# Altium schematic sheet AFE.SchDoc
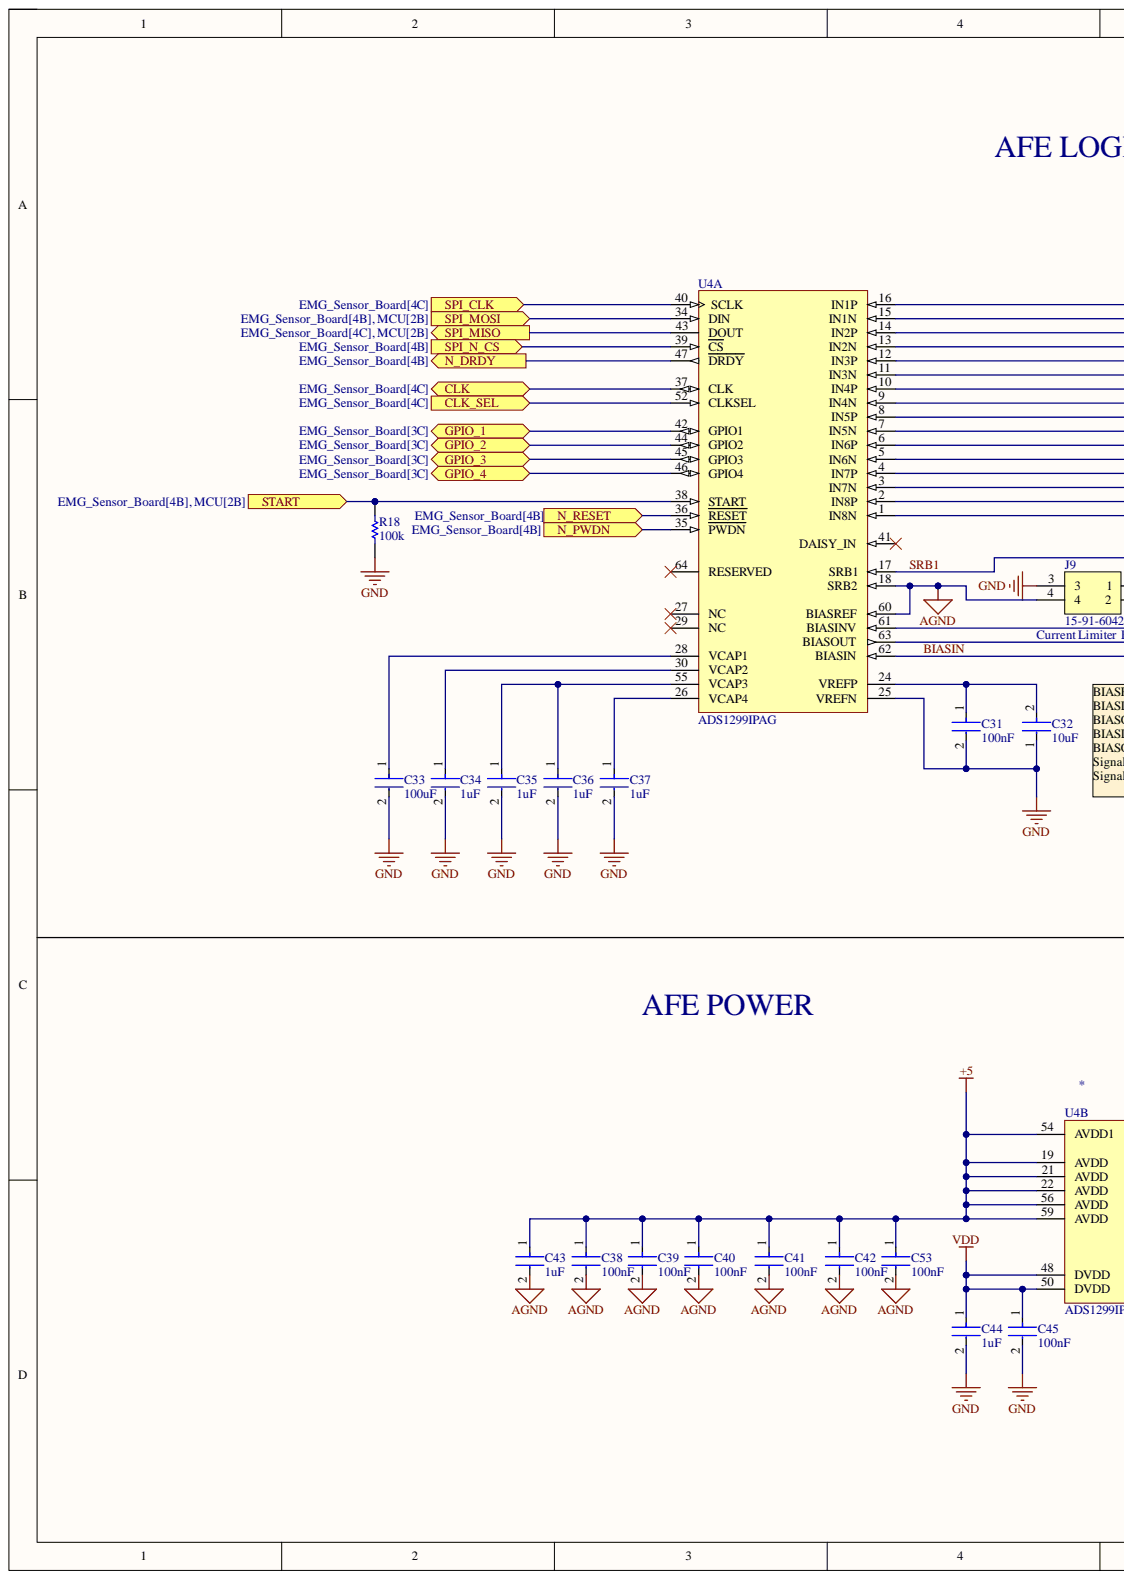
[diagram p0: AFE.SchDoc, left]
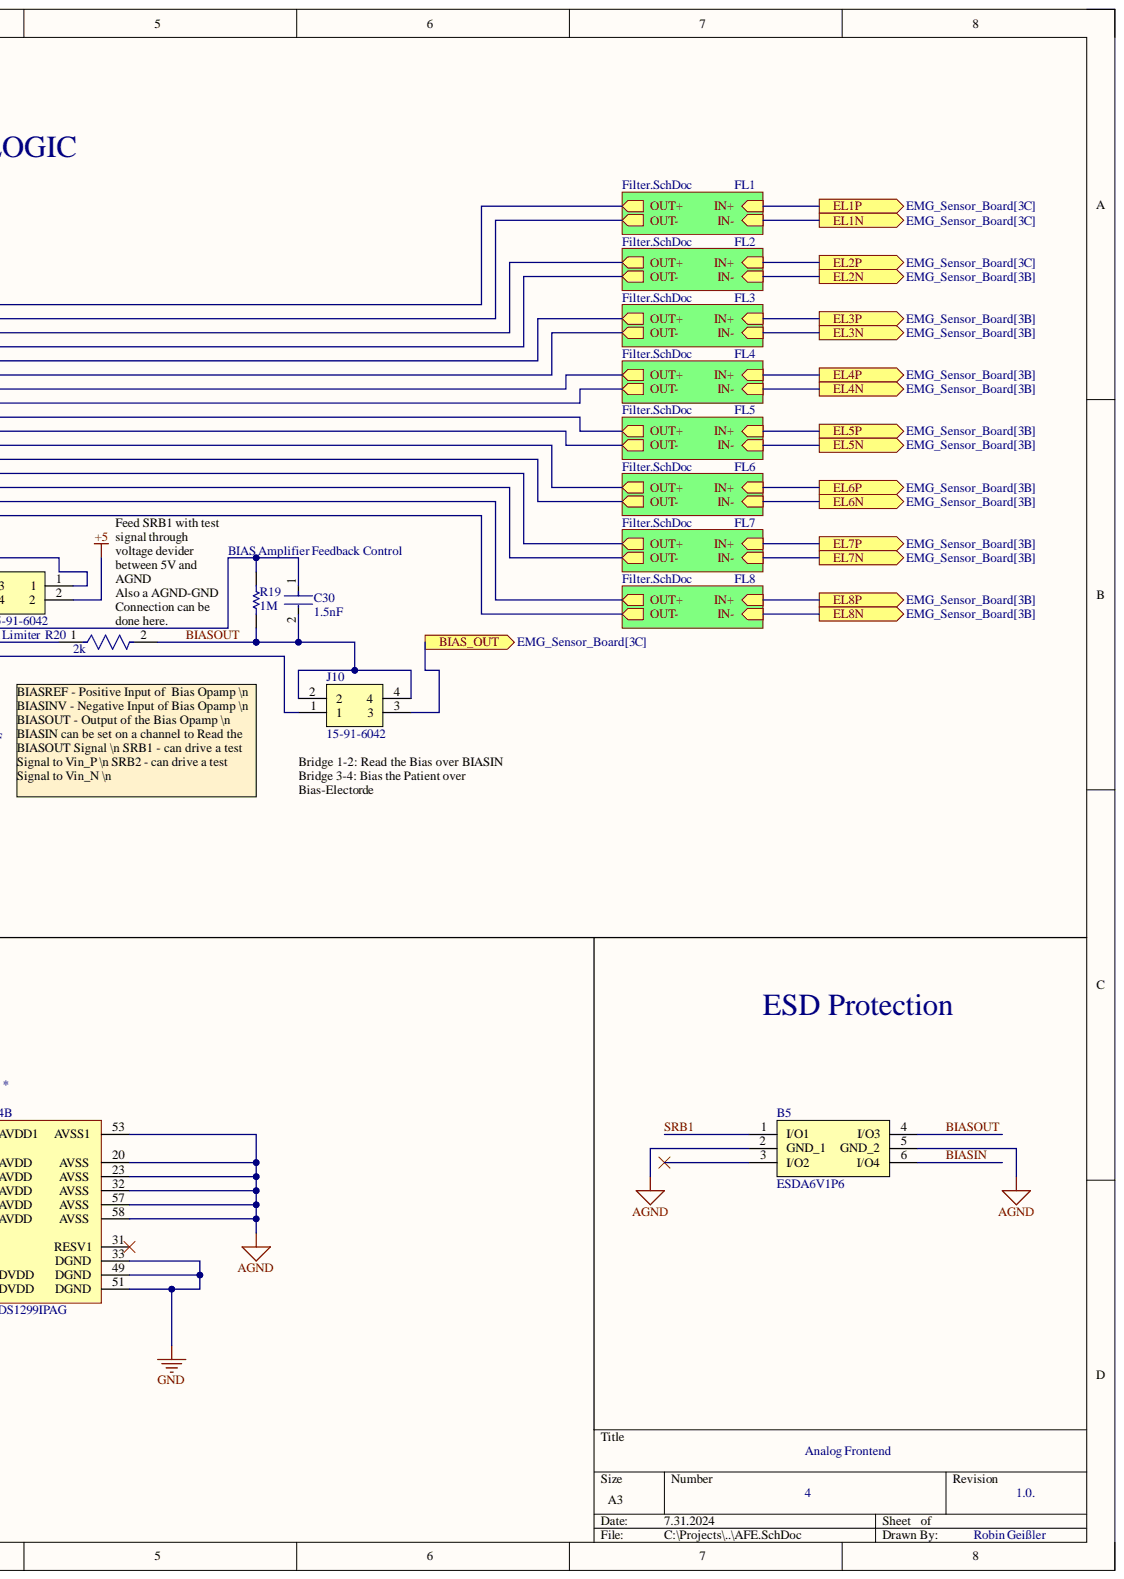
[diagram p1: AFE.SchDoc, right]

## Parts
B5  ESDA6V1P6  [ESDA6V1P6]
C30  1.5nF  [FP-GRM188-0_1-e0_2_0_5-IPC_B]
C31  100nF  [FP-CGA3E-0_3-0_1-IPC_A]
C32  10uF  [FP-C1608-080-0_1-MFG]
C33  100uF  [FP-C4532-280-0_4-IPC_B]
C34  1uF  [FP-CGA3E-0_3-0_1-IPC_B]
C35  1uF  [FP-CGA3E-0_3-0_1-IPC_B]
C36  1uF  [FP-CGA3E-0_3-0_1-IPC_B]
C37  1uF  [FP-CGA3E-0_3-0_1-IPC_B]
C38  100nF  [FP-CGA3E-0_3-0_1-IPC_A]
C39  100nF  [FP-CGA3E-0_3-0_1-IPC_A]
C40  100nF  [FP-CGA3E-0_3-0_1-IPC_A]
C41  100nF  [FP-CGA3E-0_3-0_1-IPC_A]
C42  100nF  [FP-CGA3E-0_3-0_1-IPC_A]
C43  1uF  [FP-CGA3E-0_3-0_1-IPC_B]
C44  1uF  [FP-CGA3E-0_3-0_1-IPC_B]
C45  100nF  [FP-CGA3E-0_3-0_1-IPC_A]
C53  100nF  [FP-CGA3E-0_3-0_1-IPC_A]
J9  15-91-6042  [15916042]
J10  15-91-6042  [15916042]
R18  100k  [RESC1609X55X30ML10T20]
R19  1M  [RESC1608X55X25LL10T15]
R20  2k  [FP-ERA3A-IPC_C]
U4  ADS1299IPAG  [PAG0064A_N]  (parts A,B)

## Ports
BIAS_OUT, CLK, CLK_SEL, EL1N, EL1P, EL2N, EL2P, EL3N, EL3P, EL4N, EL4P, EL5N, EL5P, EL6N, EL6P, EL7N, EL7P, EL8N, EL8P, GPIO_1, GPIO_2, GPIO_3, GPIO_4, N_DRDY, N_PWDN, N_RESET, SPI_CLK, SPI_MISO, SPI_MOSI, SPI_N_CS, START

## Nets
+5: C38.1 C39.1 C40.1 C41.1 C42.1 C43.1 C53.1 J9.2 U4.19(AVDD) U4.21(AVDD) U4.22(AVDD) U4.54(AVDD1) U4.56(AVDD) U4.59(AVDD)
AGND: B5.2(GND_1) B5.5(GND_2) C38.2 C39.2 C40.2 C41.2 C42.2 C43.2 C53.2 J9.4 U4.18(SRB2) U4.20(AVSS) U4.23(AVSS) U4.32(AVSS) U4.53(AVSS1) U4.57(AVSS) U4.58(AVSS) U4.60(BIASREF)
GND: C31.2 C32.1 C33.2 C34.2 C35.2 C36.2 C37.2 C44.2 C45.2 J9.3 R18.1 U4.25(VREFN) U4.33(DGND) U4.49(DGND) U4.51(DGND)
VDD: C44.1 C45.1 U4.48(DVDD) U4.50(DVDD)
BIAS_OUT: J10.3 ; port BIAS_OUT
BIASIN: B5.6(I/O4) J10.1 U4.62(BIASIN)
BIASOUT: B5.4(I/O3) C30.2 J10.2 J10.4 R19.1 R20.2
CLK: U4.37(CLK) ; port CLK
CLK_SEL: U4.52(CLKSEL) ; port CLK_SEL
GPIO_1: U4.42(GPIO1) ; port GPIO_1
GPIO_2: U4.44(GPIO2) ; port GPIO_2
GPIO_3: U4.45(GPIO3) ; port GPIO_3
GPIO_4: U4.46(GPIO4) ; port GPIO_4
N_DRDY: U4.47(DRDY) ; port N_DRDY
N_PWDN: U4.35(PWDN) ; port N_PWDN
N_RESET: U4.36(RESET) ; port N_RESET
SPI_CLK: U4.40(SCLK) ; port SPI_CLK
SPI_MISO: U4.43(DOUT) ; port SPI_MISO
SPI_MOSI: U4.34(DIN) ; port SPI_MOSI
SPI_N_CS: U4.39(CS) ; port SPI_N_CS
SRB1: B5.1(I/O1) J9.1 U4.17(SRB1)
START: R18.2 U4.38(START) ; port START
NetC30_1: C30.1 R19.2 U4.61(BIASINV)
NetC31_1: C31.1 C32.2 U4.24(VREFP)
NetC33_1: C33.1 U4.28(VCAP1)
NetC34_1: C34.1 U4.30(VCAP2)
NetC35_1: C35.1 C36.1 U4.55(VCAP3)
NetC37_1: C37.1 U4.26(VCAP4)
NetR20_1: R20.1 U4.63(BIASOUT)
IN+: ; port EL1P, port EL2P, port EL3P, port EL4P, port EL5P, port EL6P, port EL7P, port EL8P
IN-: ; port EL1N, port EL2N, port EL3N, port EL4N, port EL5N, port EL6N, port EL7N, port EL8N

Not connected: B5 3(I/O2); U4 1(IN8N) 2(IN8P) 3(IN7N) 4(IN7P) 5(IN6N) 6(IN6P) 7(IN5N) 8(IN5P) 9(IN4N) 10(IN4P) 11(IN3N) 12(IN3P) 13(IN2N) 14(IN2P) 15(IN1N) 16(IN1P) 27(NC) 29(NC) 31(RESV1) 41(DAISY_IN) 64(RESERVED)

## Library data of the parts
B5 = ESDA6V1P6: 4 line ESD protection, 6.1 V VBR, in SOT666; Manufacturer_Name: STMicroelectronics; Geometry.Height: 0.62mm; Arrow Part Number: ESDA6V1P6; Arrow Price/Stock: https://www.arrow.com/en/products/esda6v1p6/stmicroelectronics?region=nac; Component Kind: Standard; Datasheet Link: https://www.st.com/resource/en/datasheet/esda14v2bp6.pdf; Manufacturer_Part_Number: ESDA6V1P6; Mouser Part Number: 511-ESDA6V1P6; Mouser Price/Stock: https://www.mouser.co.uk/ProductDetail/STMicroelectronics/ESDA6V1P6?qs=ewe0KQ%2FSs5H0%252BSAub%2FR2ag%3D%3D; Supplier 1: Mouser; Supplier Part Number 1: 511-ESDA6V1P6; Library Name: EMG_Sensor.SchLib
C30 = CMP-06035-043535-1: Chip Multilayer Ceramic Capacitors for General Purpose, 0603, 1500pF, C0G, 30ppm/°C, 5%, 50V; Insulation Resistance: 10GR; Capacitance: 1.5nF; Case Code (Imperial): 0603; Case Code (Metric): 1608; Case/Package: 0603; Component Kind: Standard; Depth: 0.8mm; Dielectric: C0G; Dielectric Material: Ceramic; Height: 0.8mm; Lead Free: Lead Free; Length: 1.6mm; Library Name: Altium Content Vault; Material: Ceramic; Max Operating Temperature: 125°C; Min Operating Temperature: -55°C; Mount: Surface Mount; Number of Pins: 2; Package Quantity: 1; Packaging: Tape and Reel; Radiation Hardening: No; REACH SVHC: No SVHC; Temperature Coefficient: 30ppm/°C; Termination: SMD/SMT; Thickness: 0.035inch; Tolerance: 5%; Voltage: 50V; Voltage Rating: 50V; Voltage Rating (DC): 50V; Width: 0.8mm
C31 C38-C42 C45 C53 = CMP-2000-07546-2: Cap Ceramic 100nF 50V X7R 10% Pad SMD 0603 +125°C Automotive T/R; Capacitance: 100nF; Case Code (Imperial): 0603; Case Code (Metric): 1608; Case/Package: 0603; Component Kind: Standard; Composition: Ceramic; Depth: 0.8mm; Dielectric: X7R; Height: 0.8mm; Lead Free: Lead Free; Length: 1.6mm; Library Name: Altium Content Vault; Max Operating Temperature: 125°C; Min Operating Temperature: -55°C; Mount: Surface Mount; Package Quantity: 1; Packaging: Tape and Reel; Radiation Hardening: No; Ratings: AEC-Q200; REACH SVHC: No SVHC; Termination: SMD/SMT; Thickness: 0.035inch; Tolerance: 10%; Voltage: 50V; Voltage Rating: 50V; Voltage Rating (DC): 50V; Weight: 7.1E-05oz; Width: 0.8mm
C32 = CMP-2000-04979-3: Multilayer Ceramic Capacitors 10μF ±10% 10V X5R SMD 0603; Capacitance: 10uF; Case Code (Imperial): 0603; Case Code (Metric): 1608; Case/Package: 0603; Component Kind: Standard; Construction: Flat; Depth: 0.8mm; Dielectric: X5R; Dielectric Material: Ceramic; Height: 0.8mm; Lead Free: Lead Free; Length: 1.6mm; Library Name: Altium Content Vault; Max Operating Temperature: 85°C; Min Operating Temperature: -55°C; Mount: Surface Mount; Number of Pins: 2; Package Quantity: 1; Packaging: Tape and Reel; Radiation Hardening: No; REACH SVHC: No SVHC; Resistor Type: Ceramic; RoHS Compliant: Yes; Series: C; Termination: SMD/SMT; Thickness: 0.035inch; Tolerance: 10%; Voltage: 10V; Voltage Rating: 10V; Voltage Rating (DC): 10V; Weight: 7.1E-05oz; Width: 0.8mm
C33 = CMP-08246-002633-1: CAP CER 100UF 6.3V X6S 1812; Dielectric Material: Ceramic; Tolerance: 20%; Capacitance: 100uF; Case Code (Imperial): 1812; Case Code (Metric): 4532; Case/Package: 1812; Component Kind: Standard; Lead Free: Lead Free; Length: 0.177inch; Library Name: Altium Content Vault; Max Operating Temperature: 105°C; Min Operating Temperature: -55°C; Mount: Surface Mount; Package Quantity: 500; Packaging: Tape and Reel; RoHS Compliant: Yes; Series: C; Thickness: 0.122inch; Voltage Rating: 6.3V; Voltage Rating (DC): 6.3V; Width: 0.126inch
C34-C37 C43 C44 = CMP-08246-002551-1: Cap Ceramic 1uF 35V X7R 10% Pad SMD 0603 +125°C Automotive T/R; Capacitance: 1uF; Case Code (Imperial): 0603; Case Code (Metric): 1608; Case/Package: 0603; Component Kind: Standard; Dielectric: X7R; Height: 0.8mm; Length: 0.063inch; Library Name: Altium Content Vault; Max Operating Temperature: 125°C; Min Operating Temperature: -55°C; Mount: Surface Mount; Package Quantity: 4000; Packaging: Tape and Reel; Ratings: AEC-Q200; Termination: SMD/SMT; Thickness: 0.035inch; Tolerance: 10%; Voltage Rating: 35V; Voltage Rating (DC): 35V; Weight: 7.1E-05oz; Width: 0.031inch
J9 J10 = 15-91-6042: 2.54mm Pitch SL Wire-to-Board Header, Low Profile, Surface Mount, Single Row, Right-Angle, 3.05mm Pocket, Shrouded, with Press-fit Plastic Peg,  Circuits, Tin (Sn) Plating, Embossed Tape Packaging; Manufacturer_Name: Molex; Geometry.Height: 11.69mm; Component Kind: Standard; Datasheet Link: https://componentsearchengine.com/Datasheets/1/15-91-6042.pdf; Manufacturer_Part_Number: 15-91-6042; Mouser Part Number: 538-15-91-6042; Mouser Price/Stock: https://www.mouser.co.uk/ProductDetail/Molex/15-91-6042?qs=3DzawVMofg8HeBuyct9LCA%3D%3D; Library Name: EMG_Sensor.SchLib
R18 = CMP-2000-03685-1: TNPW0603100KBEEA; Manufacturer: Vishay Dale; Component Kind: Standard; Library Name: Altium Content Vault; Part Number: TNPW0603100KBEEA
R19 = CMP-1659-00056-1: Chip Resistor, 1 MOhm, +/- 1%, 100 mW, -55 to 155 degC, 0603 (1608 Metric), RoHS, Tape and Reel; Capacitance: 1 mF; Case\Package: Radial; Tolerance: 20%; Voltage Rating: 25 V; Component Kind: Standard; ComponentLink1Description: Manufacturer URL; ComponentLink1URL: http://www.yageo.com/; ComponentLink2Description: Datasheet; ComponentLink2URL: http://www.yageo.com/documents/recent/PYu-RC_Group_51_RoHS_L_04.pdf; DatasheetVersion: Rev. 4, 02/2016; Diameter: 10 mm; Height: 20 mm; Lead Pitch: 5 mm; Length: 20 mm; Library Name: Altium Content Vault; Max Operating Temperature: 85 degC; Min Operating Temperature: -40 degC; Mount: Through Hole; Package Quantity: 200; PackageDescription: 2-Pin Surface Mount Device, Body 1.6 x 0.8 mm; PackageReference: 0603; Packaging: Bulk; Radiation Hardening: No; REACH SVHC: No SVHC; RoHSCompliant: true; Voltage Rating (DC): 25 V; Width: 0 inch
R20 = CMP-2000-00945-2: RES SMD 2K OHM 0.1% 1/10W 0603; Max Power Dissipation: 100mW; Resistance: 2kR; Temperature Coefficient: 25ppm/°C; Voltage Rating: 75V; Case Code (Imperial): 0603; Case Code (Metric): 1608; Case/Package: 0603; Component Kind: Standard; Construction: Rectangular; Contact Plating: Tin; Depth: 0.8mm; Height: 0.45mm; Lead Free: Lead Free; Length: 1.6mm; Library Name: Altium Content Vault; Max Operating Temperature: 155°C; Military Standard: Not; Min Operating Temperature: -55°C; Mount: Surface Mount; Number of Pins: 2; Number of Terminations: 2; Package Quantity: 5000; Packaging: Tape and Reel; Power Rating: 100mW; Radiation Hardening: No; REACH SVHC: No SVHC; Resistance Tolerance: 0.1%; Resistor Type: Thin Film; RoHS Compliant: Yes; Series: ERA-3A; Technology: THIN FILM; Termination: SMD/SMT; Tolerance: 0.1%; Voltage Rating (DC): 75V; Width: 0.8mm; Working Voltage: 75V
U4 = CMP-0841-00002-2: Low-Noise, 8-Channel, 24-Bit Analog Front-End for Biopotential Measurement, 16 KSPS, -40 to 85 degC, 64-Pin PQFP (PAG-64), RoHS, Tube; Manufacturer: Texas Instruments; # Input Channels: 8; Architecture: Delta-Sigma; Interface: Serial SPI; Packing: PAG0064A; Reference Mode: Int, Ext; Resolution (Bits): 24; Sample Rate (max) (KSPS): 16; Component Kind: Standard; ComponentLink1Description: Manufacturer URL; ComponentLink1URL: http://www.ti.com/; ComponentLink2Description: Datasheet; ComponentLink2URL: http://www.ti.com/lit/ds/symlink/ads1299.pdf; ComponentLink3Description: Package Specification; ComponentLink3URL: http://www.ti.com/lit/ml/mtqf006a/mtqf006a.pdf; DatasheetVersion: SBAS499A; Library Name: Altium Content Vault; Mounting Technology: Surface Mount; Operating Temperature Range (C): -40 to 85; PackageDescription: 64-Pin Plastic Quad Flatpack, Body 10 x 10 mm, Pitch 0.5 mm; PackageReference: PAG-64; PackageVersion: 4040282/C; PartNumber: ADS1299IPAG; RoHS: TRUE
